# Altium schematic sheet LORA_ANT.SchDoc(LORA_ANT)
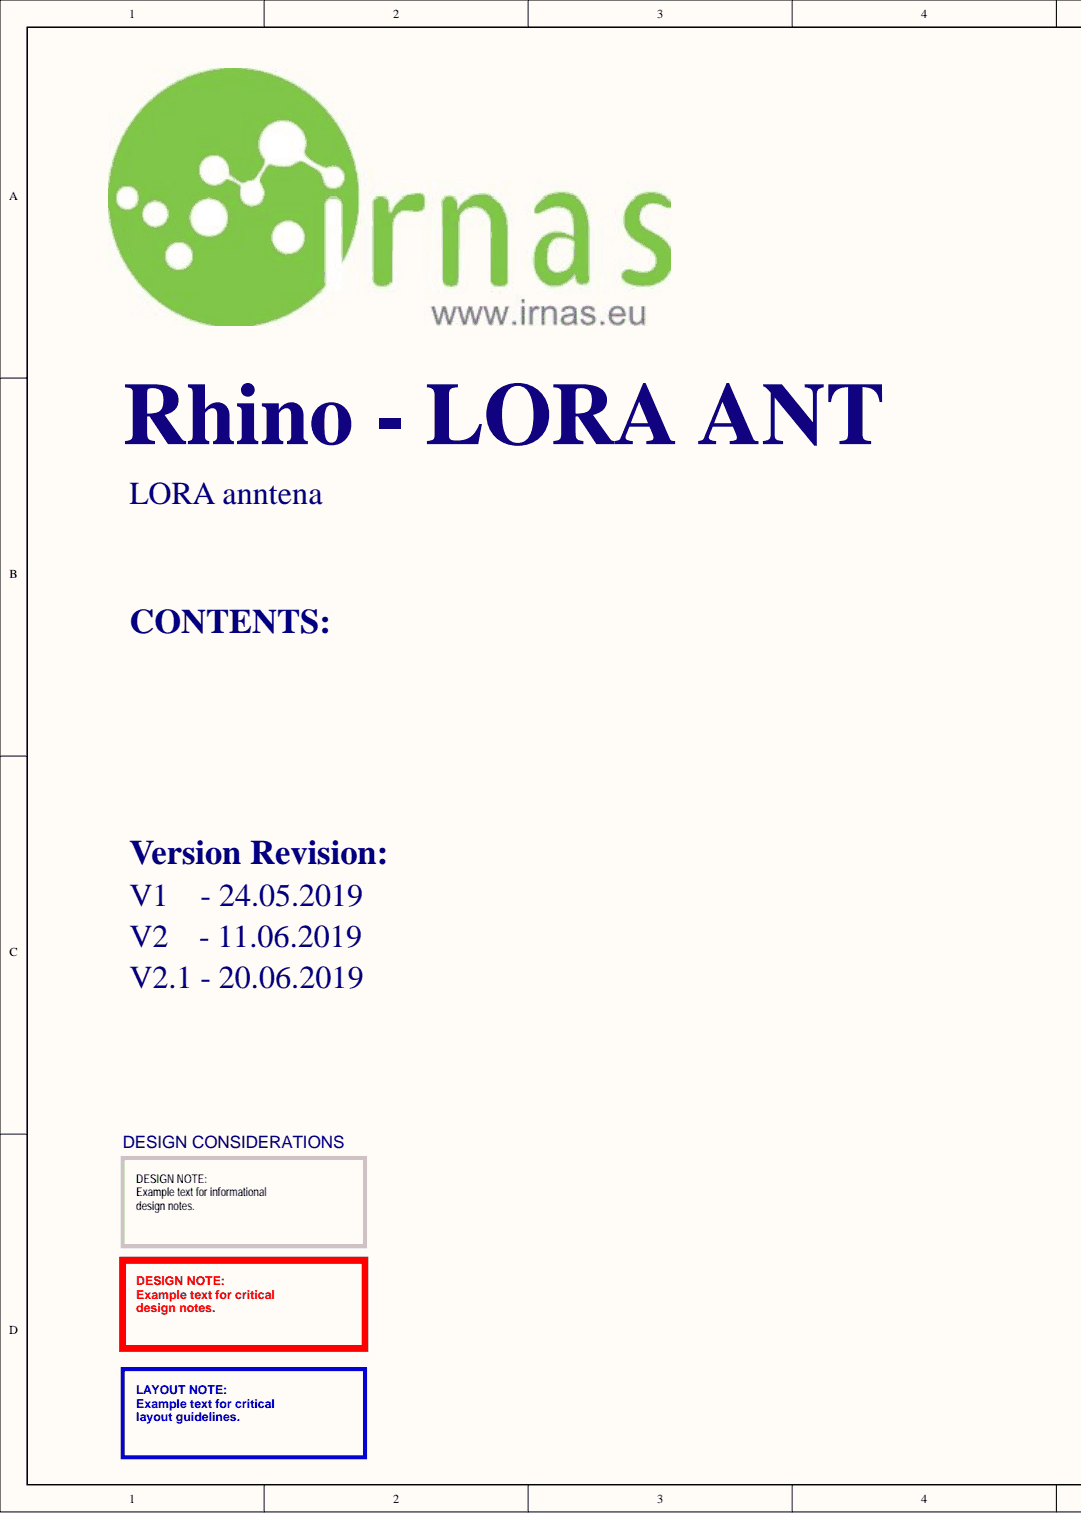
[diagram p0: LORA_ANT.SchDoc, left]
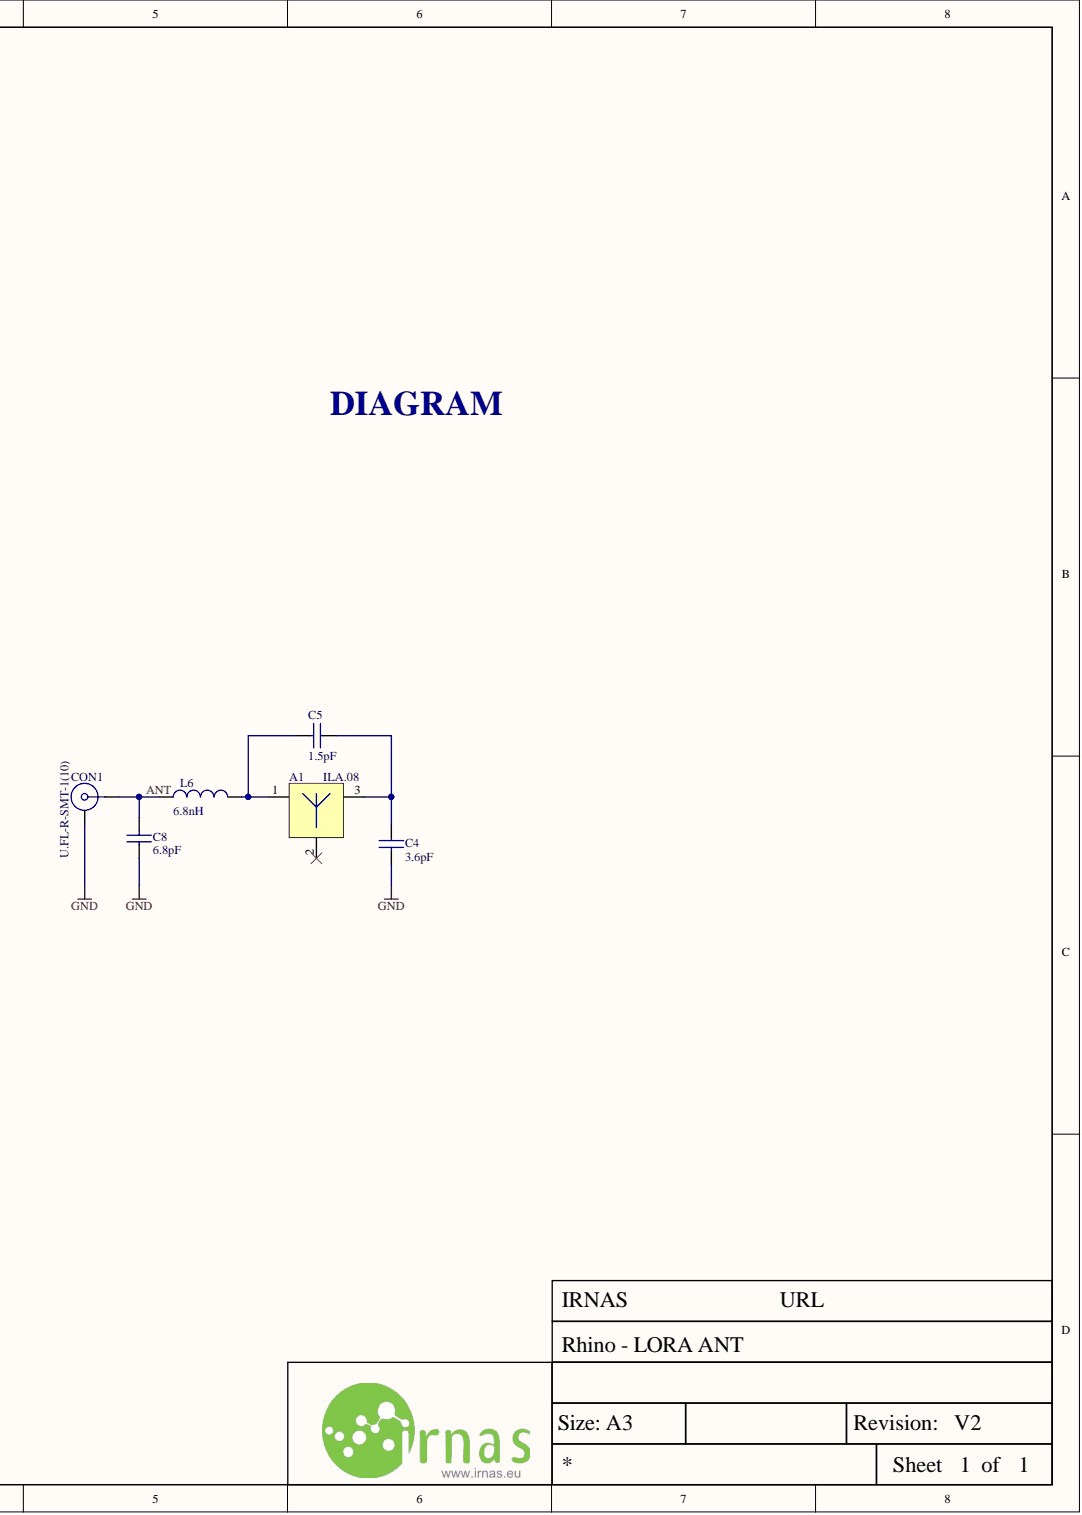
[diagram p1: LORA_ANT.SchDoc, right]

## Parts
A1  ILA.08  [ILA.08_LORA]
C4  3.6pF  [CAP0402]
C5  1.5pF  [CAP0402]
C8  6.8pF  [CAP0402]
CON1  U.FL-R-SMT-1(10)  [ufl connector smd]
L6  6.8nH  [IND0402]

## Nets
GND: C4.2 C8.1 CON1.2
ANT: C8.2 CON1.1 L6.1
NetA1_1: A1.1 C5.2 L6.2
NetA1_3: A1.3 C4.1 C5.1

Not connected: A1 2

## Library data of the parts
A1 = ANT - ILA.08: Antenna, ISM/Lora/Lpwan/Sigfox, 868Mhz Center Frequency, 5mm x 3mm x 0.5mm Dimensions; Manufacturer Part Number: SR42I010-R; Manufacturer: Antenova; Supplier Part Number 2: 960-CGGP.18.2.A.02; Supplier Part Number 1: 931-1408-ND; Supplier 2: Mouser; Supplier 1: Digi-Key; Stock 1: 3154; Pricing 1: 1 = 2.36000, 10 = 1.90000, 50 = 1.90000, 100 = 1.52000, 1000 = 1.07000, 10000 = 0.95900 (USD); Library Name: Passive.SchLib; ComponentLink1URL: http://www.farnell.com/datasheets/2647030.pdf?_ga=2.119696523.2249963.1562564392-1373726713.1554121096&_gac=1.91489000.1562763802.CjwKCAjwmZbpBRAGEiwADrmVXm8xT8OHDZvJe8qZIQyyGmIuiUD-TEITatw0hIdDkyBwIXXCH7oneRoCl7cQAvD_BwE; ComponentLink1Description: Datasheet; Component Kind: Standard
C4 C5 C8 = C - 1.8pF/0402: Multilayer Ceramic Capacitors MLCC - SMD/SMT 50volts 1.8pF C0G; Manufacturer Part Number: C0402C189B5GACTU; Manufacturer: KEMET; IRNAS Part No.: /; Voltage Rating (DC): 50V; Voltage Rating: 50V; Termination: SMD/SMT; Supplier Part Number 1: 2820847; Supplier 1: Farnell; Stock 1: 8950; Pricing 1: 10=0.463, 100=0.248, 500=0.182, 2500=0.153, 5000=0.134, 10000=0.11, 50000=0.0961 (EUR); Packaging: Tape and Reel; Min Operating Temperature: -55°C; Max Operating Temperature: 125°C; Library Name: Passive.SchLib; Lead Free: Lead Free; Dielectric Material: Ceramic; ComponentLink1URL: https://datasheet.ciiva.com/5413/kem-c1003-c0g-smd-5413366.pdf; ComponentLink1Description: https://datasheet.ciiva.com/5413/kem-c1003-c0g-smd-5413366.pdf; Component Kind: Standard; Case Code (Metric): 1005; Case Code (Imperial): 0402; Case/Package: 0402; Capacitance: 1.8pF
CON1 = U.FL-R-SMT-1(10): RF / Coaxial Connector, U.FL Coaxial, Straight Jack, Surface Mount Vertical, 50 ohm, Brass; Manufacturer: Hirose; Shield Termination: Solder; Other Names: H11891TR  Q5400621  U.FL-R-SMT-1 10  U.FL-R-SMT-1(10)-ND  UFLRSMT110; Online Catalog: UMCC (Ultra Miniature Coax Connectors), U.FL Series; Impedance: 50 Ohm; Frequency - Max: 6GHz; Featured Product: U.FL Series Connectors; Dielectric Material: Liquid Crystal Polymer (LCP); Connector Type: Receptacle, Male Pin; Connector Style: U.FL, Ultra Miniature Coaxial; Center Contact Plating: Gold; Body Material: Phosphor Bronze; Body Finish: Silver; Voltage Rating: -; Supplier Part Number 1: 1688077; Supplier 1: Farnell; Stock 1: 0; Standard Package: 2,500; Series: U.FL; Packaging: Tape & Reel (TR); Operating Temperature: -40°C ~ 90°C; Mounting Type: Surface Mount; Manufacturer Part Number: U.FL-R-SMT-1(10); Library Name: Connectors.SchLib; Ingress Protection: -; Housing Color: Beige; Features: -; Fastening Type: Snap-On; Family: Coaxial Connectors (RF); Contact Termination: Solder; ComponentLink3URL: http://www.farnell.com/datasheets/1947917.pdf; ComponentLink3Description: http://www.farnell.com/datasheets/1947917.pdf; ComponentLink2URL: http://uk.rs-online.com/webdocs/1363/0900766b81363563.pdf; ComponentLink2Description: http://uk.rs-online.com/webdocs/1363/0900766b81363563.pdf; ComponentLink1URL: http://www.farnell.com/datasheets/91524.pdf; ComponentLink1Description: http://www.farnell.com/datasheets/91524.pdf; Component Kind: Standard; Center Contact Material: Brass; Category: Connectors, Interconnects; Cable Group: -
L6 = L - 4.3nH/0402: Inductor RF Chip Multi-Layer 4.3nH 0.3nH 100MHz 8Q-Factor Air 300mA 210mOhm DCR 0402 Paper T/R; Manufacturer Part Number: LQG15HN4N3S02D; Manufacturer: Murata; IRNAS Part No.: /; Shielding: Unshielded; Self Resonant Frequency: 6GHz; Q Factor: 8; DC Resistance (DCR): 210mR; Width: 0.02inch; Test Frequency: 100MHz; Termination: SMD/SMT; Supplier Part Number 1: 1711735; Supplier 1: Farnell; Stock 1: 3502; RoHS: Yes; REACH SVHC: No SVHC; Radiation Hardening: No; Pricing 1: 1 = 0.10000, 10 = 0.06100, 100 = 0.04040, 500 = 0.03366, 1000 = 0.02827, 2500 = 0.02423 (USD); Packaging: Tape and Reel; Package Quantity: 10000; Mount: Surface Mount; Min Operating Temperature: -55°C; Military Standard: Not; Max Operating Temperature: 125°C; Max DC Current: 300mA; Library Name: Passive.SchLib; Length: 1.05mm; Lead Free: Lead Free; Inductance: 4.3nh; Height - Seated (Max): 0.022inch; Height: 0.5mm; Depth: 0.55mm; DC Current: 300mA; Current Rating: 300mA; Current: 300mA; Core Material: Air; ComponentLink6URL: https://search.murata.co.jp/Ceramy/image/img/P02/partnumberingAT_LQ3E.pdf?src-supplier=Digi-Key; ComponentLink6Description: https://search.murata.co.jp/Ceramy/image/img/P02/partnumberingAT_LQ3E.pdf?src-supplier=Digi-Key; ComponentLink5URL: http://search.murata.co.jp/Ceramy/image/img/PDF/ENG/L0075S0083LQG15HN.pdf?src-supplier=Avnet+Europe; ComponentLink5Description: http://search.murata.co.jp/Ceramy/image/img/PDF/ENG/L0075S0083LQG15HN.pdf?src-supplier=Avnet+Europe; ComponentLink4URL: https://www.verical.com/server-webapp/html/getDatasheet?partID=31252&src-supplier=Verical; ComponentLink4Description: https://www.verical.com/server-webapp/html/getDatasheet?partID=31252&src-supplier=Verical; ComponentLink3URL: http://www.farnell.com/datasheets/66026.pdf?src-supplier=Element14; ComponentLink3Description: http://www.farnell.com/datasheets/66026.pdf?src-supplier=Element14; ComponentLink2URL: http://search.murata.co.jp/Ceramy/image/img/PDF/ENG/L0075S0083LQG15HN.pdf?src-supplier=Avnet; ComponentLink2Description: http://search.murata.co.jp/Ceramy/image/img/PDF/ENG/L0075S0083LQG15HN.pdf?src-supplier=Avnet; ComponentLink1URL: http://uk.rs-online.com/webdocs/0f10/0900766b80f10808.pdf?src-supplier=RSComponents; ComponentLink1Description: http://uk.rs-online.com/webdocs/0f10/0900766b80f10808.pdf?src-supplier=RSComponents; Component Kind: Standard; Case Code (Metric): 1005; Case Code (Imperial): 0402; Case/Package: 0402
